# Altium schematic sheet KC4D_EXT_GYRO_V1.2.SchDoc(KC4D_EXT_GYRO_V1.2)
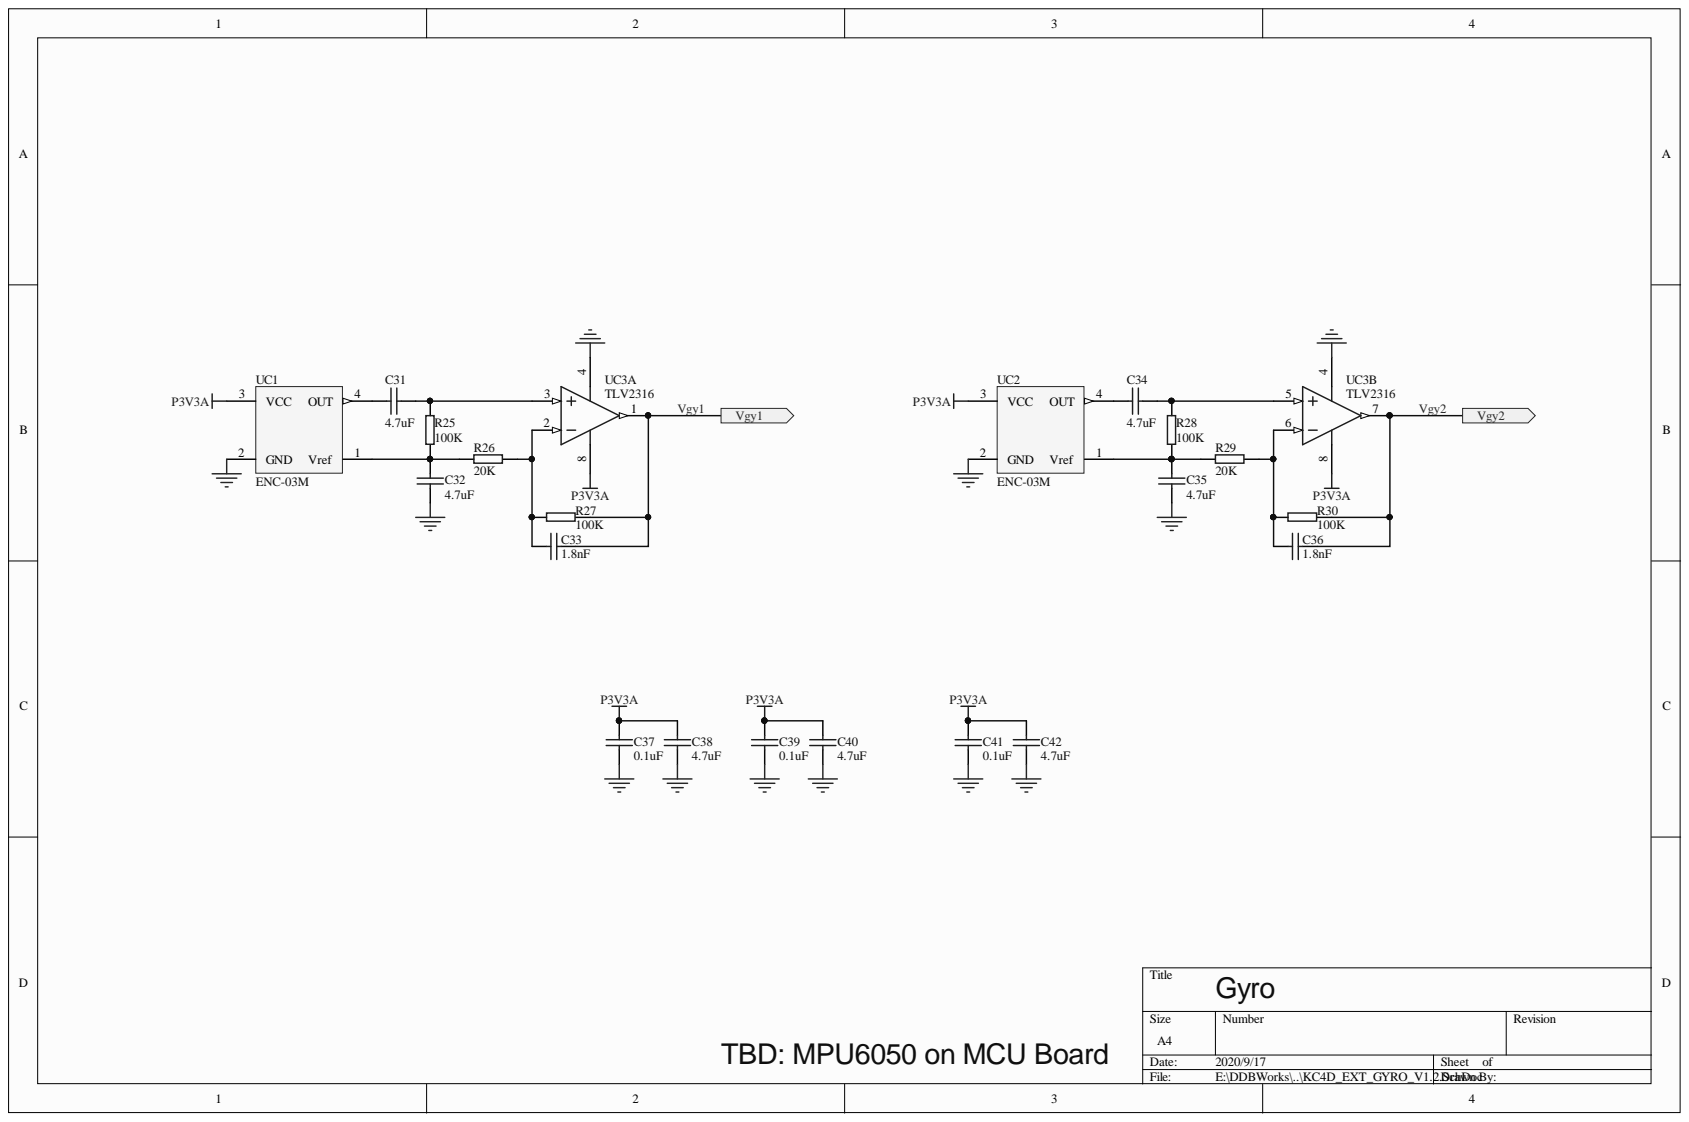
[diagram p0: KC4D_EXT_GYRO_V1.2.SchDoc]

## Parts
C31  4.7uF  [C0603J]
C32  4.7uF  [C0603J]
C33  1.8nF  [C0603J]
C34  4.7uF  [C0603J]
C35  4.7uF  [C0603J]
C36  1.8nF  [C0603J]
C37  0.1uF  [C0603J]
C38  4.7uF  [C0603J]
C39  0.1uF  [C0603J]
C40  4.7uF  [C0603J]
C41  0.1uF  [C0603J]
C42  4.7uF  [C0603J]
R25  100K  [R0603J]
R26  20K  [R0603J]
R27  100K  [R0603J]
R28  100K  [R0603J]
R29  20K  [R0603J]
R30  100K  [R0603J]
UC1  ENC-03M  [ENC03M]
UC2  ENC-03M  [ENC03M]
UC3  TLV2316  [TI-D8_N]  (parts A,B)

## Ports
Vgy1, Vgy2

## Nets
GND: C32.1 C35.1 C37.2 C38.2 C39.2 C40.2 C41.2 C42.2 UC1.2(GND) UC2.2(GND) UC3.4
P3V3A: C37.1 C38.1 C39.1 C40.1 C41.1 C42.1 UC1.3(VCC) UC2.3(VCC) UC3.8
Vgy1: C33.1 R27.1 UC3.1 ; port Vgy1
Vgy2: C36.1 R30.1 UC3.7 ; port Vgy2
NetC31_1: C31.1 UC1.4(OUT)
NetC31_2: C31.2 R25.2 UC3.3
NetC32_2: C32.2 R25.1 R26.2 UC1.1(Vref)
NetC33_2: C33.2 R26.1 R27.2 UC3.2
NetC34_1: C34.1 UC2.4(OUT)
NetC34_2: C34.2 R28.2 UC3.5
NetC35_2: C35.2 R28.1 R29.2 UC2.1(Vref)
NetC36_2: C36.2 R29.1 R30.2 UC3.6

## Library data of the parts
C31-C42 = CAP: PCB3D: 0805_0_0000_C; Part Field 4: 30pF; Part Field 3: Altium Limited; Part Field 2: July-2002: Re-released for DXP Platform.; Part Field 1: 8-Jun-2000; Component Kind: Standard
R25-R30 = Ra_DTE: PCB3D: 0805_0_0000_R; Library Name: DTE1.SchLib; Component Kind: Standard
UC3 = LM6132AIMX: Dual Low Power 10 MHz Rail-to-Rail I/O Operational Amplifier, 8-pin Narrow SOIC; Manufacturer: National Semiconductor; PowerWise: N; PackageTopMarking: NSZXTT*LM61*32AIM; CompType: Amplifiers; Simulation: LM6132A; RoHS: N; Published: 1-Mar-2010; PinCount: 8; PartNumber: LM6132AIMX; PackageVersion: revM, May-2009; PackageReference: M08A; PackageDescription: SOIC Narrow, 4.9 x 3.9 x 1.45mm, 8 Lead, 1.27mm Pitch; Library Name: NSC Amplifier.IntLib; DatasheetVersion: Nov-2004; ComponentLink3URL: http://www.national.com/packaging/folders/m08a.html; ComponentLink3Description: M08A Package Page; ComponentLink2URL: http://www.national.com/pf/LM/LM6132.html; ComponentLink2Description: LM6132 Web Page; ComponentLink1URL: http://www.national.com/ds/LM/LM6132.pdf; ComponentLink1Description: LM6132 Datasheet; Component Kind: Standard; Code_JEDEC: MS-012-AA; Code_IPC: SOIC127P600-8; Publisher: Altium Limited
UC1 UC2 = ENC-03M: Library Name: DTE1.SchLib; Component Kind: Standard
